# Altium schematic sheet Analog_inputs.SchDoc(Analog_inputs)
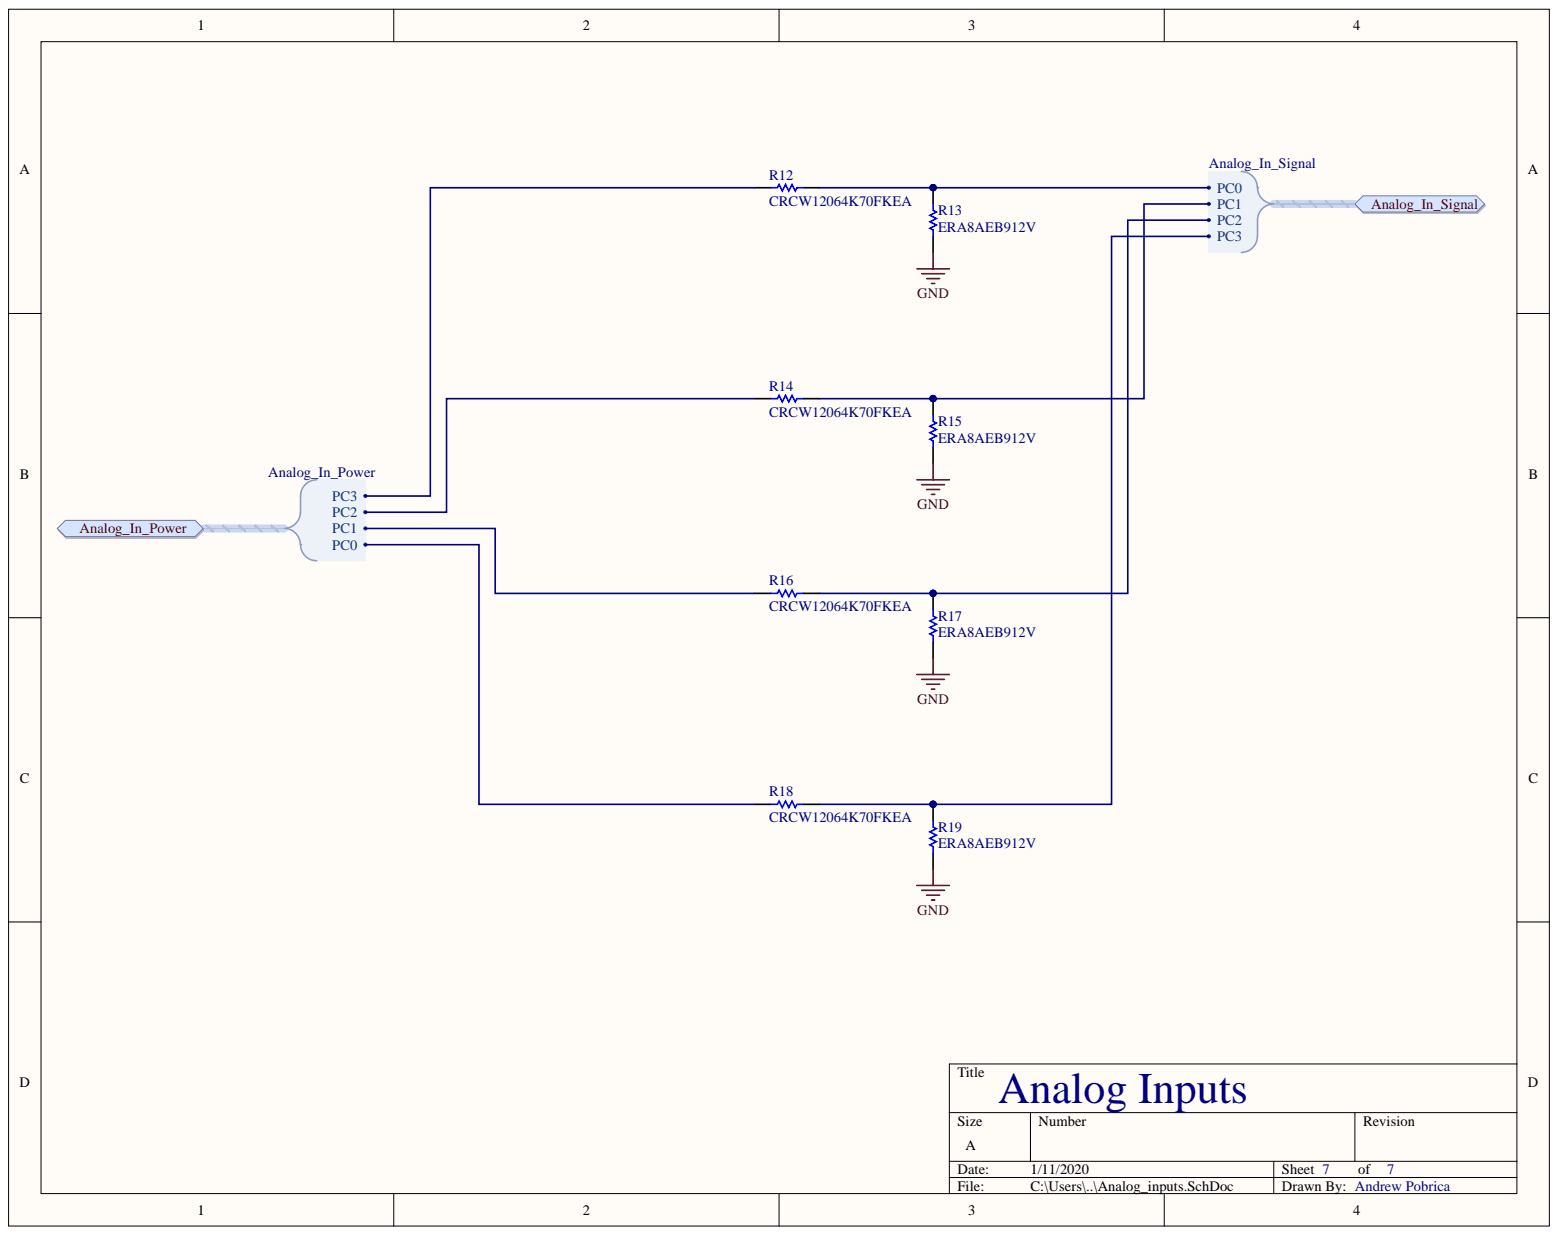
[diagram p0: Analog_inputs.SchDoc]

## Parts
R12  CRCW12064K70FKEA  [RESC3216X60X45ML15T20]
R13  ERA8AEB912V  [RESC3216X70X50ML20T25]
R14  CRCW12064K70FKEA  [RESC3216X60X45ML15T20]
R15  ERA8AEB912V  [RESC3216X70X50ML20T25]
R16  CRCW12064K70FKEA  [RESC3216X60X45ML15T20]
R17  ERA8AEB912V  [RESC3216X70X50ML20T25]
R18  CRCW12064K70FKEA  [RESC3216X60X45ML15T20]
R19  ERA8AEB912V  [RESC3216X70X50ML20T25]

## Ports
Analog_In_Power, Analog_In_Power.PC0, Analog_In_Power.PC1, Analog_In_Power.PC2, Analog_In_Power.PC3, Analog_In_Signal, Analog_In_Signal.PC0, Analog_In_Signal.PC1, Analog_In_Signal.PC2, Analog_In_Signal.PC3

## Nets
GND: R13.2 R15.2 R17.2 R19.2
NetR12_1: R12.1 R13.1 ; port Analog_In_Signal
NetR12_2: R12.2 ; port Analog_In_Power
NetR14_1: R14.1 R15.1 ; port Analog_In_Signal
NetR14_2: R14.2 ; port Analog_In_Power
NetR16_1: R16.1 R17.1 ; port Analog_In_Signal
NetR16_2: R16.2 ; port Analog_In_Power
NetR18_1: R18.1 R19.1 ; port Analog_In_Signal
NetR18_2: R18.2 ; port Analog_In_Power

## Library data of the parts
R12 R14 R16 R18 = CMP-2100-03618-1: Chip Resistor, 4.7 KOhm, +/- 1%, 0.25 W, -55 to 155 degC, 1206 (3216 Metric), RoHS, Tape and Reel; Manufacturer: Vishay Semiconductor; Voltage Rating (DC): 200V; Temperature Coefficient: 100ppm/degreesC; Power Rating: 250mW; Width: 1.6mm; Weight: 0.000353oz; Voltage Rating: 200V; Tolerance: 1%; Termination: SMD/SMT; RoHSCompliant: true; Resistance: 4.7KOhm; REACH SVHC: Unknown; Radiation Hardening: No; Packaging: TapeandReel; Package Reference: 1206.0; Package Quantity: 10; Package Description: 2-Pin Surface Mount Device, Body 3.15 x 1.6 mm; Number of Terminations: 2; Mount: SurfaceMount; Min Operating Temperature: -55degC; Military Standard: Not; Max Power Dissipation: 250mW; Max Operating Temperature: 155degC; Manufacturer URL: http://www.vishay.com/; Library Name: Altium Content Vault; Length: 3.2mm; Lead Free: LeadFree; Height: 0.55mm; Depth: 1.6mm; Datasheet Version: 41061.0; Datasheet URL: http://www.vishay.com/docs/20035/dcrcwe3.pdf; Contact Plating: Tin; Composition: ThickFilm; Component Kind: Standard; Case Code (Metric): 3216; Case Code (Imperial): 1206; Case/Package: 1206
R13 R15 R17 R19 = CMP-2003-00013-1: Manufacturer: Panasonic; Part Number: ERA8AEB912V; Library Name: Altium Content Vault; Component Kind: Standard
